# Altium schematic sheet Dip_Switch.SchDoc(Dip_Switch)
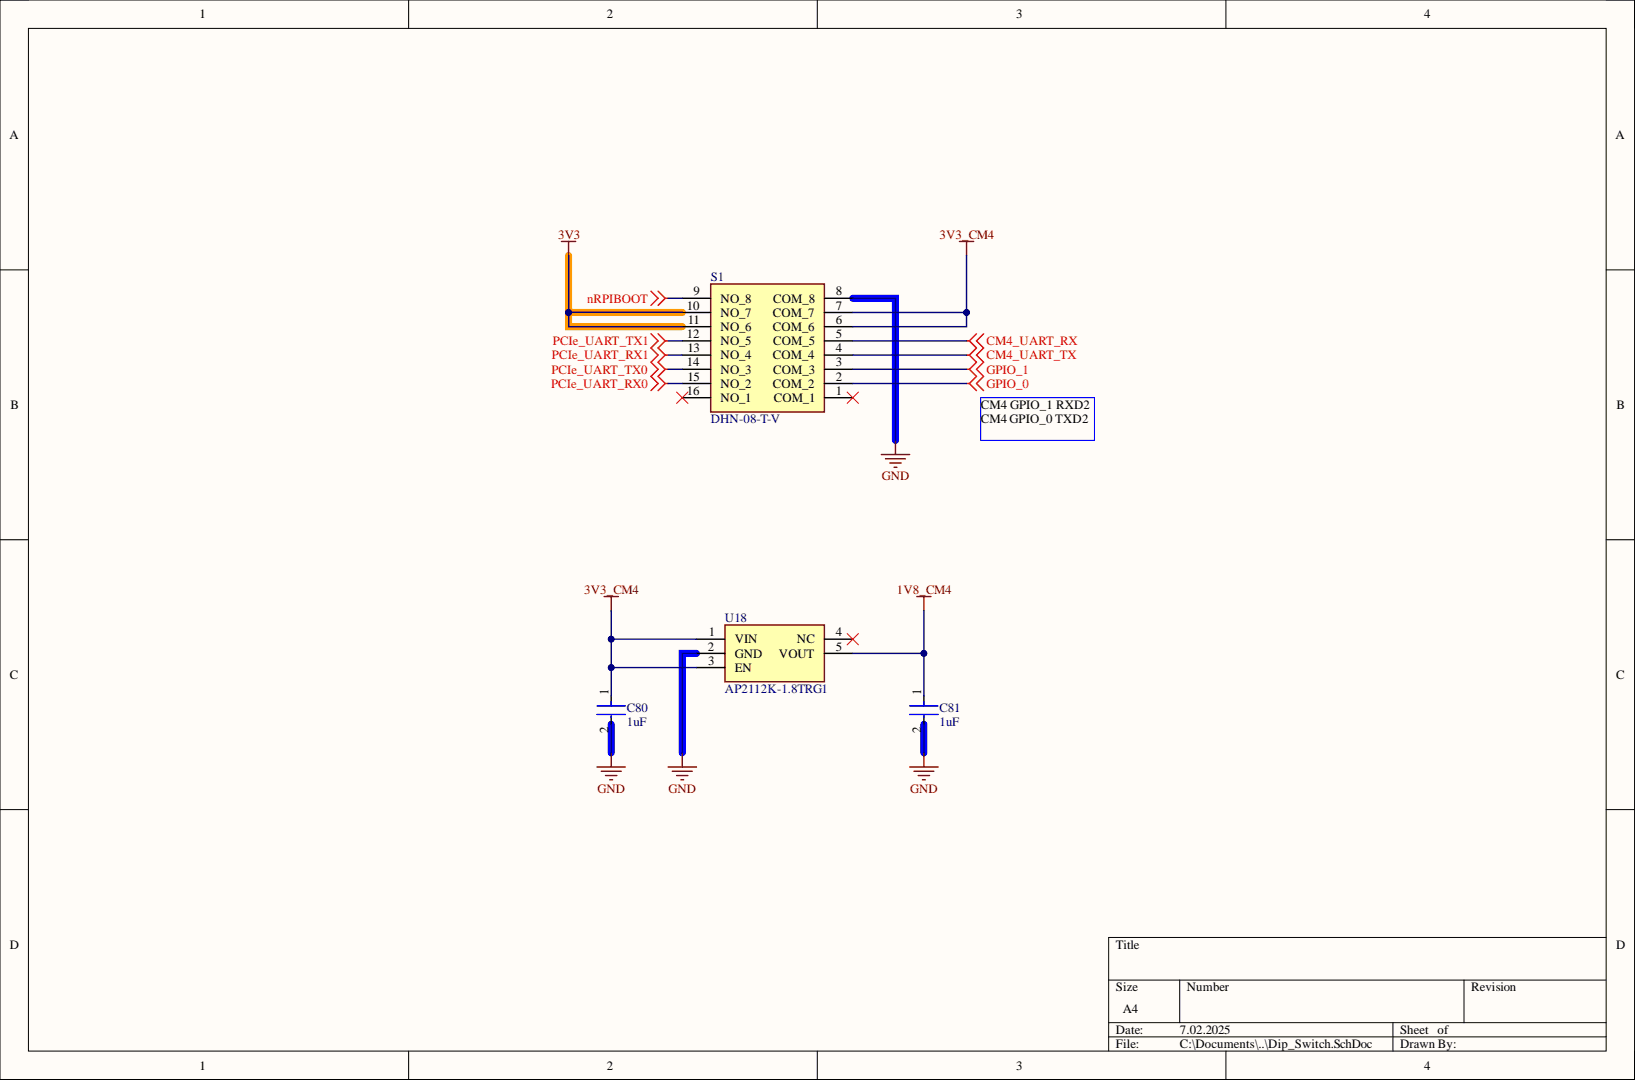
[diagram p0: Dip_Switch.SchDoc]

## Parts
C80  1uF  [FP-CC0805-0_85-MFG]
C81  1uF  [FP-CC0805-0_85-MFG]
S1  DHN-08-T-V  [DHN08TV]
U18  AP2112K-1.8TRG1  [SOT95P285X140-5N]

## Nets
1V8_CM4: C81.1 U18.5(VOUT)
3V3: S1.10(NO_7) S1.11(NO_6)
3V3_CM4: C80.1 S1.6(COM_6) S1.7(COM_7) U18.1(VIN) U18.3(EN)
CM4_UART_RX: S1.5(COM_5)
CM4_UART_TX: S1.4(COM_4)
GND: C80.2 C81.2 S1.8(COM_8) U18.2(GND)
GPIO_0: S1.2(COM_2)
GPIO_1: S1.3(COM_3)
nRPIBOOT: S1.9(NO_8)
PCIe_UART_RX0: S1.15(NO_2)
PCIe_UART_RX1: S1.13(NO_4)
PCIe_UART_TX0: S1.14(NO_3)
PCIe_UART_TX1: S1.12(NO_5)

Not connected: S1 1(COM_1) 16(NO_1); U18 4(NC)

## Library data of the parts
C80 C81 = CMP-03422-000182-1: CAP CER 1UF 10V X7R 0805; Manufacturer: Yageo; Manufacturer Part Number: CC0805KKX7R6BB105; Material: Ceramic; Tolerance: 0.1; Automotive: No; Capacitance: 1 uF; Category: Cap; Component Kind: Standard; ComponentLink2Description: Datasheet; ComponentLink2URL: http://www.yageo.com/documents/recent/UPY-GPHC_Y5V_6.3V-to-50V_8.pdf; Device Class L1: Passive Components; Device Class L2: Capacitors; Device Class L3: Ceramic Capacitors; Digikey Description: CAP CER 1UF 10V X7R 0805; Footprint Url: http://www.yageo.com/exep/pages/download/literatures/UPY-C_GEN_24.pdf; Height: 0.95mm; Ipc Land Pattern Name: CAPC200125X85; Lead Free: Yes; Library Name: Altium Content Vault; Mouser Description: Multilayer Ceramic Capacitors MLCC - SMD/SMT 1.0uF 10V X7R 10%; Octopart: https://octopart.com/cc0805kkx7r6bb105-yageo-42522859; Package: CC0805-0.85; RoHS: TRUE; Temperature: -30°C to +85°C; Temperature Characteristic: X7R; Temperature Range High: +85°C; Temperature Range Low: -30°C; Value: 1 uF; Voltage Rating: 10 V
S1 = DHN-08-T-V: Switch; Manufacturer_Name: Diptronics; Component Kind: Standard; Datasheet Link: https://componentsearchengine.com/Datasheets/1/DHN-08-T-V.pdf; Height: 1.65mm; Manufacturer_Part_Number: DHN-08-T-V; Mouser Part Number: 113-DHN-08-T-V; Mouser Price/Stock: https://www.mouser.com/Search/Refine.aspx?Keyword=113-DHN-08-T-V
U18 = AP2112K-1.8TRG1: Integrated Circuit; Manufacturer_Name: Diodes Inc.; Arrow Part Number: AP2112K-1.8TRG1; Arrow Price/Stock: https://www.arrow.com/en/products/ap2112k-1.8trg1/diodes-incorporated?region=nac; Component Kind: Standard; Datasheet Link: https://www.diodes.com/assets/Datasheets/AP2112.pdf; Height: 1.4mm; Manufacturer_Part_Number: AP2112K-1.8TRG1; Mouser Part Number: 621-AP2112K-1.8TRG1; Mouser Price/Stock: https://www.mouser.co.uk/ProductDetail/Diodes-Incorporated/AP2112K-1.8TRG1?qs=x6A8l6qLYDBx1xhw8AX2cw%3D%3D
